# Altium schematic sheet 99Ping.SchDoc(99Ping)
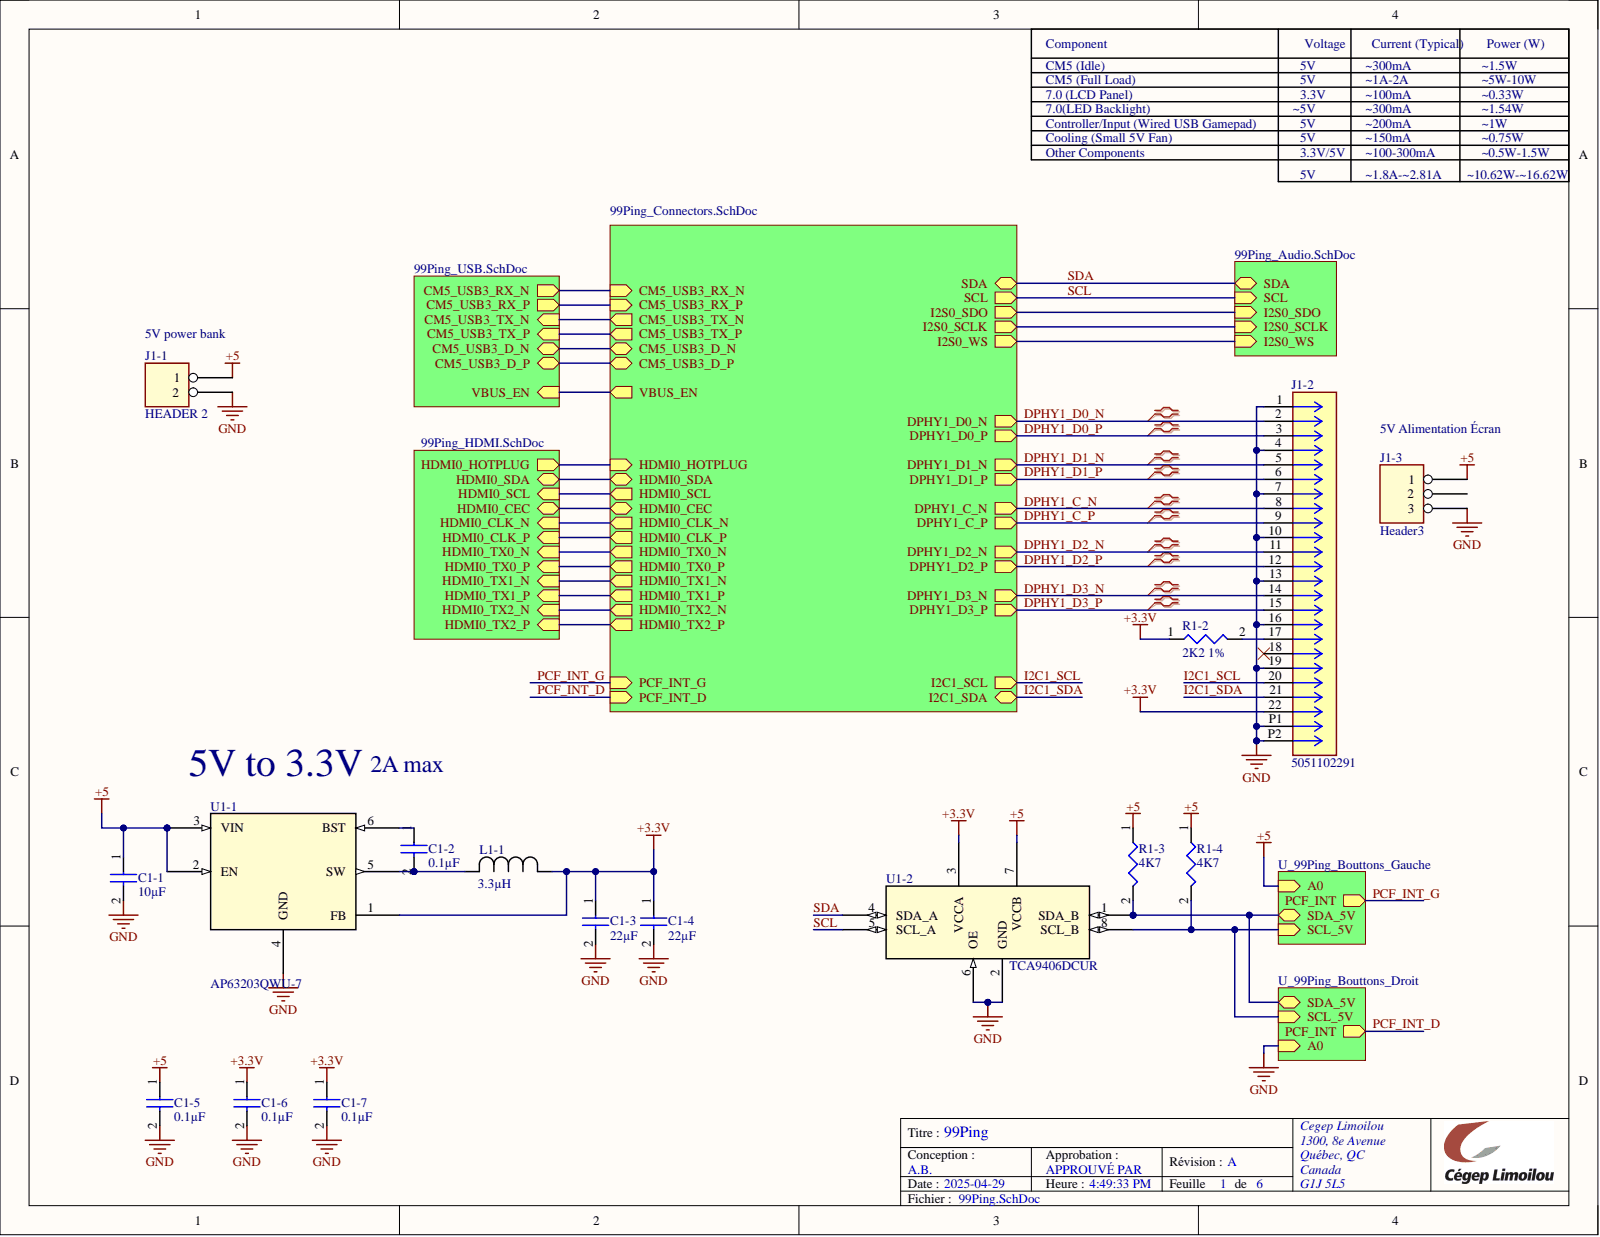
[diagram p0: 99Ping.SchDoc]

## Parts
C1-1  10µF  [CAP_NP 0603]
C1-2  0.1µF  [Cap_np 0402]
C1-3  22µF  [CAP_NP 0603]
C1-4  22µF  [CAP_NP 0603]
C1-5  0.1µF  [Cap_np 0402]
C1-6  0.1µF  [Cap_np 0402]
C1-7  0.1µF  [Cap_np 0402]
J1-1  HEADER 2  [Header2p]
J1-2  5051102291  [CON_5051102291_MOL]
J1-3  Header3  [Header 3 right angle]
L1-1  3.3µH  [IND_NRS5030_TAY3.3H]
R1-2  2K2 1%  value 2K2  [Resistor 0402]
R1-3  4K7  [Resistor 0402]
R1-4  4K7  [Resistor 0402]
U1-1  AP63203QWU-7  [AP63203QWU-7]
U1-2  TCA9406DCUR  [TCA9406DCUR]

## Nets
+3.3V: C1-3.1 C1-4.1 C1-6.1 C1-7.1 J1-2.22 L1-1.1 R1-2.1 U1-1.1(FB) U1-2.3(VCCA)
+5: C1-1.1 C1-5.1 J1-1.1 J1-3.1 R1-3.1 R1-4.1 U1-1.2(EN) U1-1.3(VIN) U1-2.7(VCCB)
GND: C1-1.2 C1-3.2 C1-4.2 C1-5.2 C1-6.2 C1-7.2 J1-1.2 J1-2.1 J1-2.4 J1-2.7 J1-2.10 J1-2.13 J1-2.16 J1-2.19 J1-2.P1 J1-2.P2 J1-3.3 U1-1.4(GND) U1-2.2(GND) U1-2.6(OE)
DPHY1_C_N: J1-2.8
DPHY1_C_P: J1-2.9
DPHY1_D0_N: J1-2.2
DPHY1_D0_P: J1-2.3
DPHY1_D1_N: J1-2.5
DPHY1_D1_P: J1-2.6
DPHY1_D2_N: J1-2.11
DPHY1_D2_P: J1-2.12
DPHY1_D3_N: J1-2.14
DPHY1_D3_P: J1-2.15
I2C1_SCL: J1-2.20
I2C1_SDA: J1-2.21
SCL: U1-2.5(SCL_A)
SDA: U1-2.4(SDA_A)
NetC1-2_1: C1-2.1 U1-1.6(BST)
NetC1-2_2: C1-2.2 L1-1.2 U1-1.5(SW)
NetJ1-2_17: J1-2.17 R1-2.2
NetJ1-2_18: J1-2.18
NetJ1-3_2: J1-3.2
NetR1-3_2: R1-3.2 U1-2.1(SDA_B)
NetR1-4_2: R1-4.2 U1-2.8(SCL_B)
CM5_USB3_D_N:
CM5_USB3_D_P:
CM5_USB3_RX_N:
CM5_USB3_RX_P:
CM5_USB3_TX_N:
CM5_USB3_TX_P:
HDMI0_CEC:
HDMI0_CLK_N:
HDMI0_CLK_P:
HDMI0_HOTPLUG:
HDMI0_SCL:
HDMI0_SDA:
HDMI0_TX0_N:
HDMI0_TX0_P:
HDMI0_TX1_N:
HDMI0_TX1_P:
HDMI0_TX2_N:
HDMI0_TX2_P:
I2S0_SCLK:
I2S0_SDO:
I2S0_WS:
PCF_INT_D:
PCF_INT_G:
VBUS_EN:

## Library data of the parts
C1-1 = CAP NP 10µF 0805: Manufacturer: Murata Electronics; Manufacturer Part Number: GRM188Z71A106KA73D; Component Kind: Standard; Digi-Key_Part_Number: 490-GRM188Z71A106KA73DCT-ND; MountType: SMT; Package: 0603; Supplier 1: Digikey; Supplier description: CAP CER 10UF 10V X7R 0603; Supplier Part Number 1: 490-GRM188Z71A106KA73DCT-ND; Value: 10µF; Library Name: 99Ping.SCHLIB
C1-2 C1-5-C1-7 = CAP NP 0.1µF 0402: CAP CER 0.1UF 10V X7R 0402; Manufacturer: Samsung Electro-Mechanics; Manufacturer Part Number: CL05B104KP5NNNC; Component Kind: Standard; Digi-Key_Part_Number: 1276-1002-1-ND; MountType: SMT; Package: 0402; Supplier 1: Digikey; Supplier description: CAP CER 0.1UF 10V X7R 0402; Supplier Part Number 1: 1276-1002-1-ND; Value: 0.1µF; Library Name: 99Ping.SCHLIB
C1-3 C1-4 = CAP NP 22µF: Manufacturer: Samsung Electro-Mechanics; Manufacturer Part Number: CL10A226MP8NUNE; Component Kind: Standard; Digi-Key_Part_Number: 1276-1274-1-ND; MountType: SMT; Package: 0603; Supplier 1: Digikey; Supplier description: CAP CER 22UF 10V X5R 0603; Supplier Part Number 1: 1276-1274-1-ND; Value: 22µF; Library Name: 99Ping.SCHLIB
J1-2 = 5051102291: 22 Position FFC, FPC Connector Contacts, Bottom 0.020" (0.50mm) Surface Mount, Right Angle; Manufacturer: Molex; Component Kind: Standard; Digi-Key_Part_Number: WM11288CT-ND; Manufacturer Part Number: 5051102291; MountType: SMT; Package: None; Supplier 1: Digikey; Supplier description: 22 Position FFC, FPC Connector Contacts, Bottom 0.020" (0.50mm) Surface Mount, Right Angle; Supplier Part Number 1: WM11288CT-ND; Library Name: 99Ping.SCHLIB
J1-3 = Header 3: Manufacturer: Adam Tech; Manufacturer Part Number: PH1RB-03-UA; Component Kind: Standard; Digi-Key_Part_Number: 2057-PH1RB-03-UA-ND; MountType: TH; Supplier 1: Digikey; Supplier description: Connector Header Through Hole, Right Angle 3 position 0.100" (2.54mm); Supplier Part Number 1: 2057-PH1RB-03-UA-ND; Library Name: 99Ping.SCHLIB
L1-1 = NRS5030T3R3MMGJ: No Description Available; Manufacturer: Taiyo Yuden; Component Kind: Standard; Digi-Key_Part_Number: 587-3459-1-ND; Manufacturer Part Number: NRS5030T3R3MMGJ; MountType: SMT; Supplier 1: Digikey; Supplier description: FIXED IND 3.3UH 3A 30 MOHM SMD; Supplier Part Number 1: 587-3459-1-ND; Value: 3.3µH; Library Name: 99Ping.SCHLIB
R1-2 = R 2K2 0402: Manufacturer: YAGEO; Manufacturer Part Number: RT0603FRE132K2L; Component Kind: Standard; Digi-Key_Part_Number: 13-RT0603FRE132K2LCT-ND; MountType: SMT; Package: 0603; Supplier 1: Digikey; Supplier description: RES 2.2K OHM 1% 1/10W 0603; Supplier Part Number 1: 13-RT0603FRE132K2LCT-ND; Library Name: 99Ping.SCHLIB
R1-3 R1-4 = R 4K7 0402: Manufacturer: Panasonic Electronic Components; Manufacturer Part Number: ERJ-2RKF4701X; Component Kind: Standard; Digi-Key_Part_Number: P4.70KLCT-ND; MountType: SMT; Package: 0402; Supplier 1: Digikey; Supplier description: RES SMD 4.7K OHM 1% 1/10W 0402; Supplier Part Number 1: P4.70KLCT-ND; Value: 4K7; Library Name: 99Ping.SCHLIB
U1-1 = AP63203QWU-7: No Description Available; Manufacturer: Diodes Incorporated; Component Kind: Standard; Digi-Key_Part_Number: 31-AP63203QWU-7CT-ND; Manufacturer Part Number: AP63203QWU-7; MountType: SMT; Package: SOT-23-6; Supplier 1: Digikey; Supplier description: IC REG BUCK 3.3V 2A TSOT23-6; Supplier Part Number 1: 31-AP63203QWU-7CT-ND; Library Name: 99Ping.SCHLIB
U1-2 = TCA9406DCUR: No Description Available; Manufacturer: Texas Instruments; Component Kind: Standard; Digi-Key_Part_Number: 296-28109-1-ND; Manufacturer Part Number: TCA9406DCUR; MountType: SMT; Package: 8-VSSOP; Supplier 1: Digikey; Supplier description: IC TRANSLTR BIDIRECTIONAL 8VSSOP; Supplier Part Number 1: 296-28109-1-ND; Library Name: 99Ping.SCHLIB
J1-1 = HEADER 2: Component Kind: Standard; Library Name: 99Ping.SCHLIB
